# Altium schematic sheet EVB-NORA-B2_SCHEM_0007_mikroE.SchDoc(EVB-NORA-B2_SCHEM_0007_mikroE)
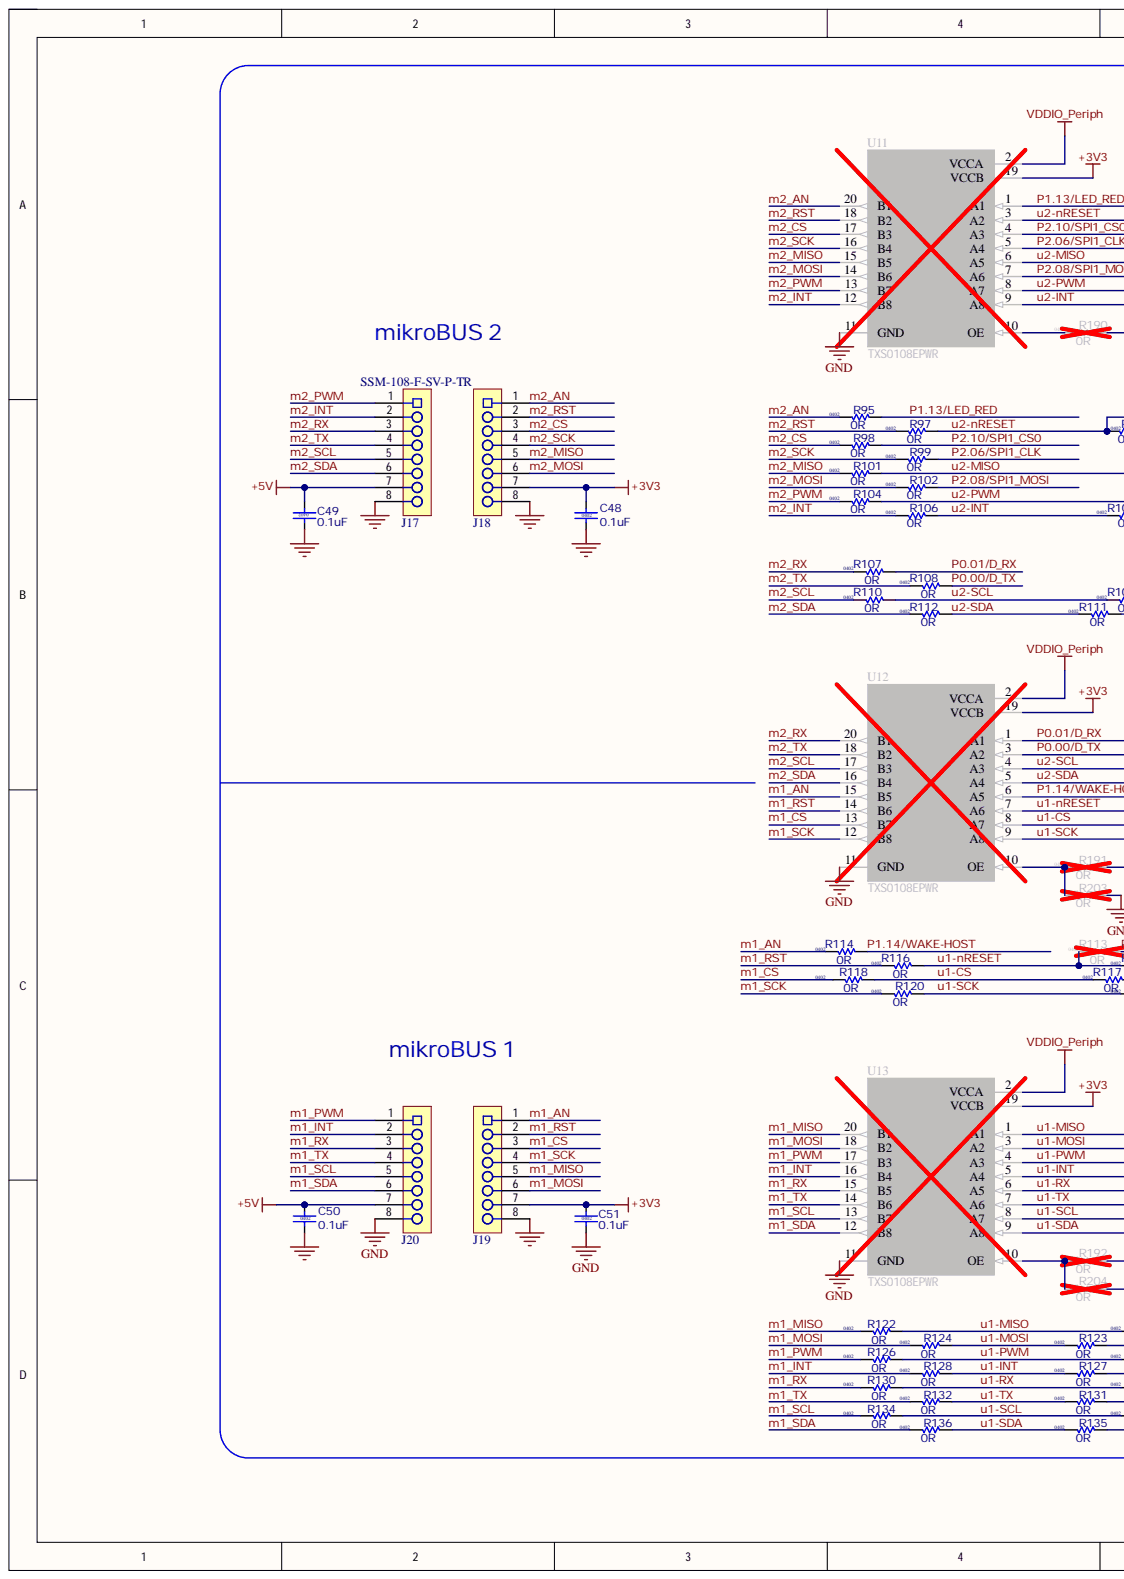
[diagram p0: EVB-NORA-B2_SCHEM_0007_mikroE.SchDoc, left]
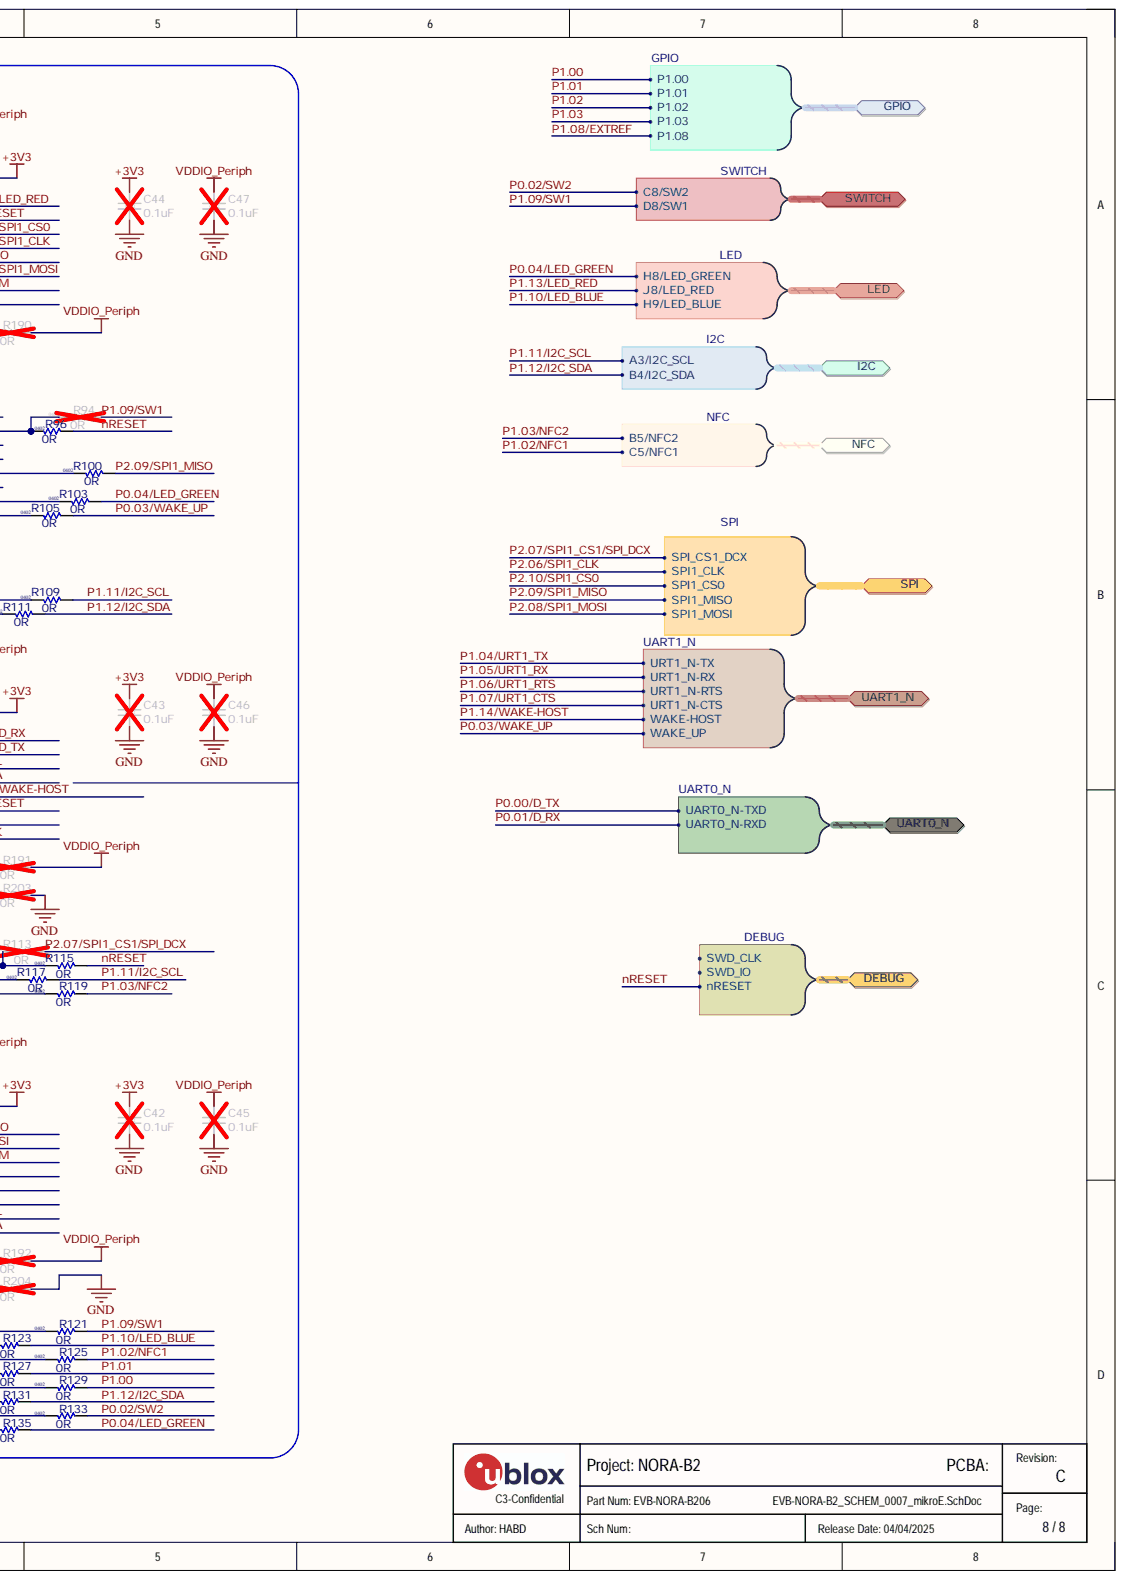
[diagram p1: EVB-NORA-B2_SCHEM_0007_mikroE.SchDoc, right]

## Parts
C42  value 0.1uF  Size: 0402  [Cap 0402 HD]  (hidden comment: 120-000160.1uF)
C43  value 0.1uF  Size: 0402  [Cap 0402 HD]  (hidden comment: 120-000160.1uF)
C44  value 0.1uF  Size: 0402  [Cap 0402 HD]  (hidden comment: 120-000160.1uF)
C45  value 0.1uF  Size: 0402  [Cap 0402 HD]  (hidden comment: 120-000160.1uF)
C46  value 0.1uF  Size: 0402  [Cap 0402 HD]  (hidden comment: 120-000160.1uF)
C47  value 0.1uF  Size: 0402  [Cap 0402 HD]  (hidden comment: 120-000160.1uF)
C48  value 0.1uF  Size: 0402  [Cap 0402 HD]  (hidden comment: 120-00016)
C49  value 0.1uF  Size: 0402  [Cap 0402 HD]  (hidden comment: 120-00016)
C50  value 0.1uF  Size: 0402  [Cap 0402 HD]  (hidden comment: 120-00016)
C51  value 0.1uF  Size: 0402  [Cap 0402 HD]  (hidden comment: 120-00016)
J17  Manufacturer Part Number 1: SSM-108-F-SV-P-TR  [SKT-0.1P-SSM-108-L-SV]  (hidden comment: 130-00102)
J18  Manufacturer Part Number 1: SSM-108-F-SV-P-TR  [SKT-0.1P-SSM-108-L-SV]  (hidden comment: 130-00102)
J19  130-00102  [SKT-0.1P-SSM-108-L-SV]  (value not drawn)
J20  130-00102  [SKT-0.1P-SSM-108-L-SV]  (value not drawn)
R94  value 0R  Size: 0402  [RES 0402 HD]
R95  value 0R  Size: 0402  [RES 0402 HD]  (hidden comment: UBXH18-0003002)
R96  value 0R  Size: 0402  [RES 0402 HD]
R97  value 0R  Size: 0402  [RES 0402 HD]  (hidden comment: UBXH18-0003002)
R98  value 0R  Size: 0402  [RES 0402 HD]  (hidden comment: UBXH18-0003002)
R99  value 0R  Size: 0402  [RES 0402 HD]  (hidden comment: UBXH18-0003002)
R100  value 0R  Size: 0402  [RES 0402 HD]
R101  value 0R  Size: 0402  [RES 0402 HD]  (hidden comment: UBXH18-0003002)
R102  value 0R  Size: 0402  [RES 0402 HD]  (hidden comment: UBXH18-0003002)
R103  value 0R  Size: 0402  [RES 0402 HD]
R104  value 0R  Size: 0402  [RES 0402 HD]  (hidden comment: UBXH18-0003002)
R105  value 0R  Size: 0402  [RES 0402 HD]
R106  value 0R  Size: 0402  [RES 0402 HD]  (hidden comment: UBXH18-0003002)
R107  value 0R  Size: 0402  [RES 0402 HD]  (hidden comment: UBXH18-0003002)
R108  value 0R  Size: 0402  [RES 0402 HD]  (hidden comment: UBXH18-0003002)
R109  value 0R  Size: 0402  [RES 0402 HD]
R110  value 0R  Size: 0402  [RES 0402 HD]  (hidden comment: UBXH18-0003002)
R111  value 0R  Size: 0402  [RES 0402 HD]
R112  value 0R  Size: 0402  [RES 0402 HD]  (hidden comment: UBXH18-0003002)
R113  value 0R  Size: 0402  [RES 0402 HD]
R114  value 0R  Size: 0402  [RES 0402 HD]  (hidden comment: UBXH18-0003002)
R115  value 0R  Size: 0402  [RES 0402 HD]
R116  value 0R  Size: 0402  [RES 0402 HD]  (hidden comment: UBXH18-0003002)
R117  value 0R  Size: 0402  [RES 0402 HD]
R118  value 0R  Size: 0402  [RES 0402 HD]  (hidden comment: UBXH18-0003002)
R119  value 0R  Size: 0402  [RES 0402 HD]
R120  value 0R  Size: 0402  [RES 0402 HD]  (hidden comment: UBXH18-0003002)
R121  value 0R  Size: 0402  [RES 0402 HD]
R122  value 0R  Size: 0402  [RES 0402 HD]  (hidden comment: UBXH18-0003002)
R123  value 0R  Size: 0402  [RES 0402 HD]
R124  value 0R  Size: 0402  [RES 0402 HD]  (hidden comment: UBXH18-0003002)
R125  value 0R  Size: 0402  [RES 0402 HD]
R126  value 0R  Size: 0402  [RES 0402 HD]  (hidden comment: UBXH18-0003002)
R127  value 0R  Size: 0402  [RES 0402 HD]
R128  value 0R  Size: 0402  [RES 0402 HD]  (hidden comment: UBXH18-0003002)
R129  value 0R  Size: 0402  [RES 0402 HD]
R130  value 0R  Size: 0402  [RES 0402 HD]  (hidden comment: UBXH18-0003002)
R131  value 0R  Size: 0402  [RES 0402 HD]
R132  value 0R  Size: 0402  [RES 0402 HD]  (hidden comment: UBXH18-0003002)
R133  value 0R  Size: 0402  [RES 0402 HD]
R134  value 0R  Size: 0402  [RES 0402 HD]  (hidden comment: UBXH18-0003002)
R135  value 0R  Size: 0402  [RES 0402 HD]
R136  value 0R  Size: 0402  [RES 0402 HD]  (hidden comment: UBXH18-0003002)
R190  value 0R  Size: 0402  [RES 0402 HD]
R191  value 0R  Size: 0402  [RES 0402 HD]
R192  value 0R  Size: 0402  [RES 0402 HD]
R203  value 0R  Size: 0402  [RES 0402 HD]
R204  value 0R  Size: 0402  [RES 0402 HD]
U11  value TXS0108EPWR  Manufacturer P/N: TXS0108EPWR  [TSOP0.65P6.40X1.20-20Pin]
U12  value TXS0108EPWR  Manufacturer P/N: TXS0108EPWR  [TSOP0.65P6.40X1.20-20Pin]
U13  value TXS0108EPWR  Manufacturer P/N: TXS0108EPWR  [TSOP0.65P6.40X1.20-20Pin]

## Ports
DEBUG, DEBUG.nRESET, DEBUG.SWD_CLK, DEBUG.SWD_IO, GPIO, GPIO.P1.00, GPIO.P1.01, GPIO.P1.02, GPIO.P1.03, GPIO.P1.08, I2C, I2C.A3/I2C_SCL, I2C.B4/I2C_SDA, LED, LED.H8/LED_GREEN, LED.H9/LED_BLUE, LED.J8/LED_RED, NFC, NFC.B5/NFC2, NFC.C5/NFC1, SPI, SPI.SPI1_CLK, SPI.SPI1_CS0, SPI.SPI1_MISO, SPI.SPI1_MOSI, SPI.SPI_CS1_DCX, SWITCH, SWITCH.C8/SW2, SWITCH.D8/SW1, UART0_N, UART0_N.UART0_N-RXD, UART0_N.UART0_N-TXD, UART1_N, UART1_N.URT1_N-CTS, UART1_N.URT1_N-RTS, UART1_N.URT1_N-RX, UART1_N.URT1_N-TX, UART1_N.WAKE-HOST, UART1_N.WAKE_UP

## Nets
+3V3: C42.1 C43.1 C44.1 C48.1 C51.1 J18.7 J19.7 U11.19(VCCB) U12.19(VCCB) U13.19(VCCB)
+5V: C49.2 C50.1 J17.7 J20.7
GND: C42.2 C43.2 C44.2 C45.2 C46.2 C47.2 C48.2 C49.1 C50.2 C51.2 J17.8 J18.8 J19.8 J20.8 R203.2 R204.2 U11.11(GND) U12.11(GND) U13.11(GND)
VDDIO_Periph: C45.1 C46.1 C47.1 R190.2 R191.2 R192.2 U11.2(VCCA) U12.2(VCCA) U13.2(VCCA)
m1_AN: J19.1 R114.1 U12.15(B5)
m1_CS: J19.3 R118.1 U12.13(B7)
m1_INT: J20.2 R128.1 U13.16(B4)
m1_MISO: J19.5 R122.1 U13.20(B1)
m1_MOSI: J19.6 R124.1 U13.18(B2)
m1_PWM: J20.1 R126.1 U13.17(B3)
m1_RST: J19.2 R116.1 U12.14(B6)
m1_RX: J20.3 R130.1 U13.15(B5)
m1_SCK: J19.4 R120.1 U12.12(B8)
m1_SCL: J20.5 R134.1 U13.13(B7)
m1_SDA: J20.6 R136.1 U13.12(B8)
m1_TX: J20.4 R132.1 U13.14(B6)
m2_AN: J18.1 R95.1 U11.20(B1)
m2_CS: J18.3 R98.1 U11.17(B3)
m2_INT: J17.2 R106.1 U11.12(B8)
m2_MISO: J18.5 R101.1 U11.15(B5)
m2_MOSI: J18.6 R102.1 U11.14(B6)
m2_PWM: J17.1 R104.1 U11.13(B7)
m2_RST: J18.2 R97.1 U11.18(B2)
m2_RX: J17.3 R107.1 U12.20(B1)
m2_SCK: J18.4 R99.1 U11.16(B4)
m2_SCL: J17.5 R110.1 U12.17(B3)
m2_SDA: J17.6 R112.1 U12.16(B4)
m2_TX: J17.4 R108.1 U12.18(B2)
nRESET: R96.2 R115.2 ; port DEBUG
P0.00/D_TX: R108.2 U12.3(A2) ; port UART0_N
P0.01/D_RX: R107.2 U12.1(A1) ; port UART0_N
P0.02/SW2: R133.2 ; port SWITCH
P0.03/WAKE_UP: R105.2 ; port UART1_N
P0.04/LED_GREEN: R103.2 R135.2 ; port LED
P1.00: R129.2 ; port GPIO
P1.01: R127.2 ; port GPIO
P1.02/NFC1: R125.2 ; port NFC
P1.03/NFC2: R119.2 ; port NFC
P1.09/SW1: R94.2 R121.2 ; port SWITCH
P1.10/LED_BLUE: R123.2 ; port LED
P1.11/I2C_SCL: R109.2 R117.2 ; port I2C
P1.12/I2C_SDA: R111.2 R131.2 ; port I2C
P1.13/LED_RED: R95.2 U11.1(A1) ; port LED
P1.14/WAKE-HOST: R114.2 U12.6(A5) ; port UART1_N
P2.06/SPI1_CLK: R99.2 U11.5(A4) ; port SPI
P2.07/SPI1_CS1/SPI_DCX: R113.2 ; port SPI
P2.08/SPI1_MOSI: R102.2 U11.7(A6) ; port SPI
P2.09/SPI1_MISO: R100.2 ; port SPI
P2.10/SPI1_CS0: R98.2 U11.4(A3) ; port SPI
u1-CS: R117.1 R118.2 U12.8(A7)
u1-INT: R127.1 R128.2 U13.5(A4)
u1-MISO: R121.1 R122.2 U13.1(A1)
u1-MOSI: R123.1 R124.2 U13.3(A2)
u1-nRESET: R113.1 R115.1 R116.2 U12.7(A6)
u1-PWM: R125.1 R126.2 U13.4(A3)
u1-RX: R129.1 R130.2 U13.6(A5)
u1-SCK: R119.1 R120.2 U12.9(A8)
u1-SCL: R133.1 R134.2 U13.8(A7)
u1-SDA: R135.1 R136.2 U13.9(A8)
u1-TX: R131.1 R132.2 U13.7(A6)
u2-INT: R105.1 R106.2 U11.9(A8)
u2-MISO: R100.1 R101.2 U11.6(A5)
u2-nRESET: R94.1 R96.1 R97.2 U11.3(A2)
u2-PWM: R103.1 R104.2 U11.8(A7)
u2-SCL: R109.1 R110.2 U12.4(A3)
u2-SDA: R111.1 R112.2 U12.5(A4)
NetR190_1: R190.1 U11.10(OE)
NetR191_1: R191.1 R203.1 U12.10(OE)
NetR192_1: R192.1 R204.1 U13.10(OE)
N00019: ; port DEBUG
N00021: ; port DEBUG
P1.02: ; port GPIO
P1.03: ; port GPIO
P1.04/URT1_TX: ; port UART1_N
P1.05/URT1_RX: ; port UART1_N
P1.06/URT1_RTS: ; port UART1_N
P1.07/URT1_CTS: ; port UART1_N
P1.08/EXTREF: ; port GPIO

## Library data of the parts
C42-C51 = 120-00016: Cap, Ceramic 0.1uF 10V 10% X5R 0402; Manufacturer: Murata Electronics North America; Manufacturer P/N: GRM155R61A104KA01J; Part Name: CAP CER 0.1UF 10V 10% X5R 0402; Component Mounting Type: SMT; Database Table Name: Ceramic Capacitor; Item_Phase: Production; Tolerance: +/- 10%; Use in new designs: Yes; Arena Link: https://app.bom.com/a/GYHPV2L2L43KRMBK?v=spec.summary; Component Kind: Standard; Height: 0.55mm; Manufacturer 2: Yageo; Manufacturer P/N 2: CC0402KRX5R6BB104; Part Number: 120-00016; Part_Type: Ceramic Capacitor; Pins: 2; Revision: 0A; Signal Integrity: Cap Semi; Simulation: CAP; Supplier 1: Digi-Key; Supplier 2: Digi-Key; Supplier Part Number 1: 490-6297-1-ND; Supplier Part Number 2: 311-1336-1-ND; Temperature Coefficient: X5R; Voltage: 10V; Library Name: Arena_Parts_DB_Lib.DbLib
J17 J18 = 130-00102: Socket Strip 0.1" 8 Pin 1Row SMD Black; Manufacturer 1: Samtec; Part Name: CON SKT Strip 0.1" 8Pin 1Row SMD Black; Database Table Name: Connector; Item Phase: In Production; UBX Code: None; Use in new designs: Yes; Voltage: 572VDC; Component Kind: Standard; Diameter: None; Height: 7.37mm; Manufacturer 2: Sullins Connector Solutions; Manufacturer Part Number 2: NPPC081KFXC-RC; Max Operating Temp: 105; Min Operating Temp: -55; Part Number: 130-00102; Pins: 8; Revision: 0D; Supplier 1: Avnet; Supplier 2: Digi-Key; Supplier Part Number 1: SSM-108-F-SV-P-TR; Supplier Part Number 2: S5639-ND; Library Name: Arena_Parts_DB_Lib.DbLib
J19 J20 = 130-00102: Socket Strip 0.1" 8 Pin 1Row SMD Black; Manufacturer 1: Samtec; Manufacturer Part Number 1: SSM-108-F-SV-P-TR; Part Name: CON SKT Strip 0.1" 8Pin 1Row SMD Black; Database Table Name: Connector; Item Phase: In Production; UBX Code: None; Use in new designs: Yes; Voltage: 572VDC; Component Kind: Standard; Diameter: None; Height: 7.37mm; Manufacturer 2: Sullins Connector Solutions; Manufacturer Part Number 2: NPPC081KFXC-RC; Max Operating Temp: 105; Min Operating Temp: -55; Part Number: 130-00102; Pins: 8; Revision: 0D; Supplier 1: Avnet; Supplier 2: Digi-Key; Supplier Part Number 1: SSM-108-F-SV-P-TR; Supplier Part Number 2: S5639-ND; Library Name: Arena_Parts_DB_Lib.DbLib
R94-R136 R190-R192 R203 R204 = UBXH18-0003002: RES THICK FILM CHIP 0402 0R 0 100mW; Manufacturer: KOA; Manufacturer P/N: RK73Z1ETTP; Database Table Name: Passive - Resistor; Editor: charlotte.biber; Env: ROHS; Mfg P/N Released: Yes; Mfg P/N Split: 100; Mfg P/N Status: NRND; Perferred: PREF; Permitted Use: Volume Products; Released by: peter.mueller; Tolerance: 0; Component Kind: Standard; Library Name: UBX_Parts_DB_Lib.DbLib; Manufacturer 2: YAGEO; Manufacturer P/N 2: 2322_705_91001_L; Max Power: 100mW; Mfg P/N Released 2: Yes; Mfg P/N Split 2: 0; Mfg P/N Status 2: NRND; Part Number: UBXH18-0003002; Part Type: Resistor; RefDes: R; Release Data: 2012-06-05 09:49:55.978616; Signal Integrity: Res; Simulation: RESISTOR; UBX Wiki: https://wiki.u-blox.com/bin/view/Operations/PartDetails?index=6611
  R94 R96 R100 R103 R105 R109 R111 R113 R115 R117 R119 R121 R123 R125 R127 R129 R131 R133 R135 R190-R192 R203 R204: Comment: = "Part Number" + Value + DNP
U11-U13 = UBXH10-0000036: TXS0108 8-BIT BIDIRECTIONAL VOLTAGE-LEVEL TRANSLATOR; Manufacturer: Texas Instruments; Database Table Name: IC - Interface; Editor: roland.rust; Env: GREEN; Mfg P/N Released: Yes; Mfg P/N Split: 100; Mfg P/N Status: Active; Perferred: PREF; Permitted Use: Support Products; Released by: adam.johansson; Comment: ="Part Number" + DNP; Component Kind: Standard; Library Name: UBX_Parts_DB_Lib.DbLib; Max Operating Temp: 85°C; Mfg P/N Datasheet: https://wiki.u-blox.com/bin/view/Generic/Docs/DocumentSummary?index=22748; Min Operating Temp: -40°C; Part Number: UBXH10-0000036; Part Type: Interface; RefDes: U; Release Data: 2015-06-17T17:08:49; UBX Wiki: https://wiki.u-blox.com/bin/view/Operations/PartDetails?index=22749
